# Altium schematic sheet WSN_project_Gateway.SchDoc
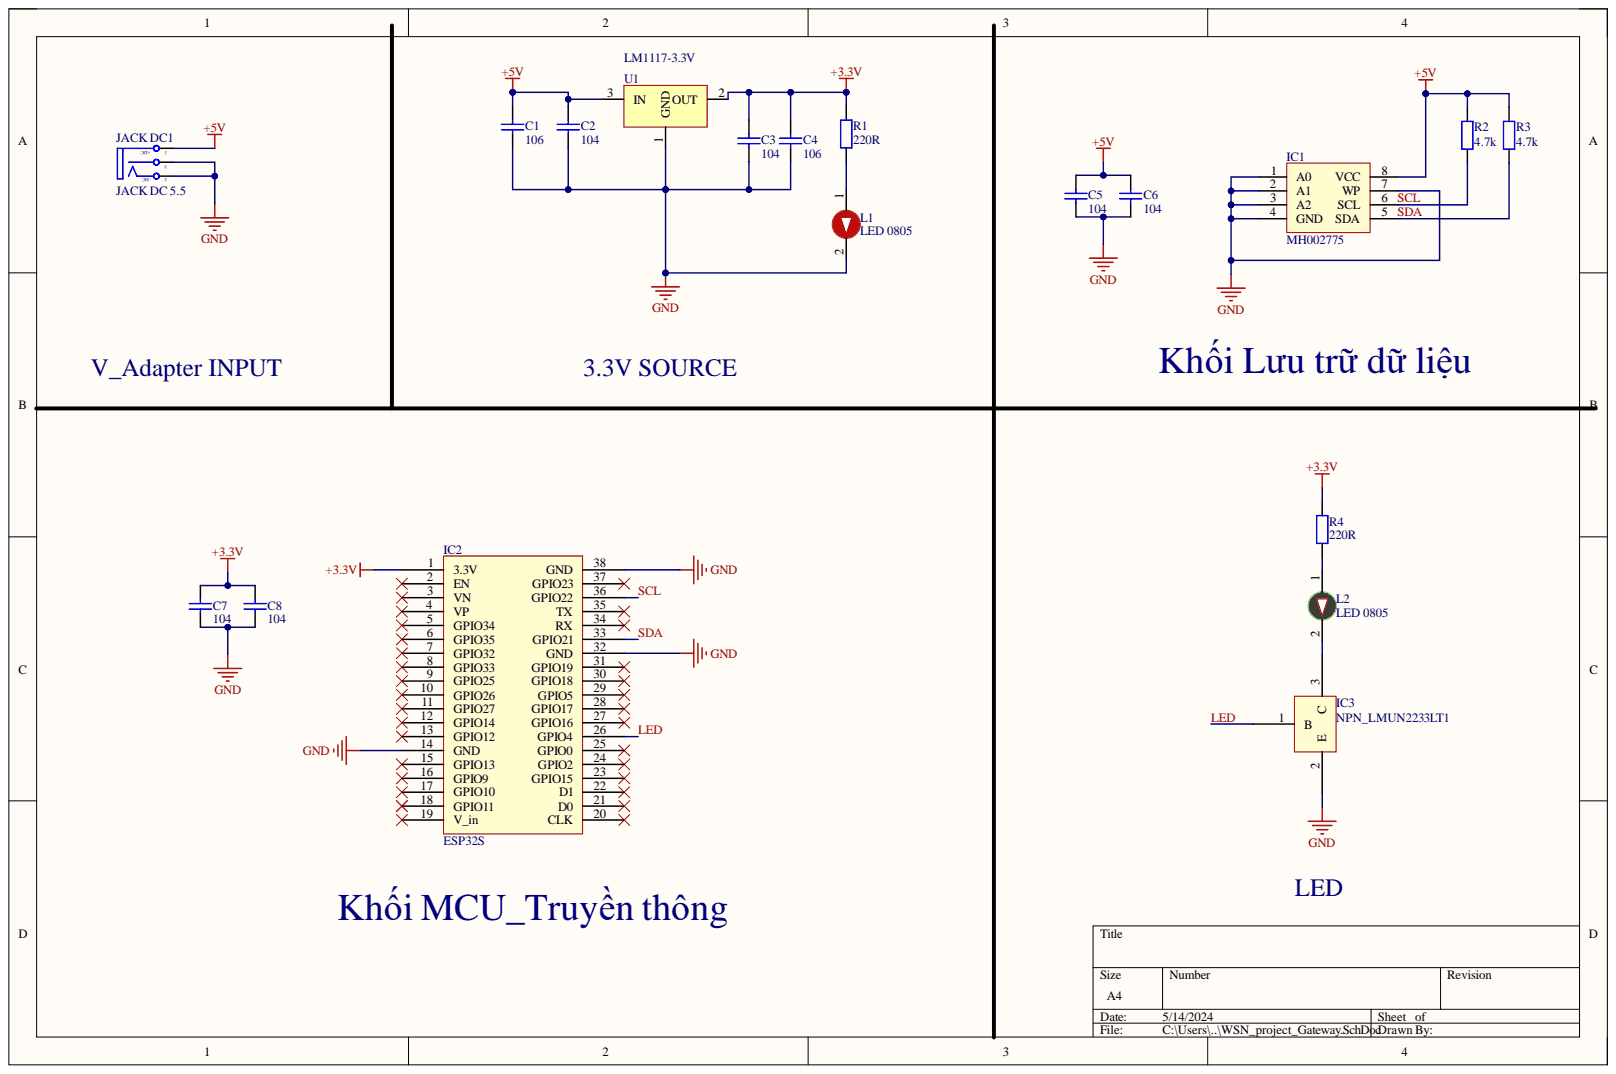
[diagram p0: WSN_project_Gateway.SchDoc]

## Parts
C1  106  [tu 1206]
C2  104  [tu 1206]
C3  104  [tu 1206]
C4  106  [tu 1206]
C5  104  [tu 1206]
C6  104  [tu 1206]
C7  104  [tu 1206]
C8  104  [tu 1206]
IC1  MH002775  [DIP8]
IC2  ESP32S  [ESP32 DEVKIT]
IC3  NPN_LMUN2233LT1  [SOT23_LMUN2233_trans]
JACK_DC1  JACK DC 5.5  [JACK DC 5.5]
L1  LED 0805  [LED-0805-Red]
L2  LED 0805  [LED-1206-Green]
R1  220R  [tro smd 0805]
R2  4.7k  [tro smd 0805]
R3  4.7k  [tro smd 0805]
R4  220R  [tro smd 0805]
U1  LM1117-3.3V  [LM1117-SOP]

## Nets
+3.3V: C3.1 C4.2 C7.1 C8.1 IC2.1(3.3V) R1.1 R4.2 U1.2
+5V: C1.2 C2.1 C5.1 C6.1 IC1.8(VCC) JACK_DC1.1 R2.2 R3.2 U1.3
GND: C1.1 C2.2 C3.2 C4.1 C5.2 C6.2 C7.2 C8.2 IC1.1(A0) IC1.2(A1) IC1.3(A2) IC1.4(GND) IC1.7(WP) IC2.14(GND) IC2.32(GND) IC2.38(GND) IC3.2 JACK_DC1.2 JACK_DC1.3 L1.2 U1.1
LED: IC2.26(GPIO4) IC3.1
SCL: IC1.6(SCL) IC2.36(GPIO22) R2.1
SDA: IC1.5(SDA) IC2.33(GPIO21) R3.1
NetIC3_3: IC3.3 L2.2
NetL1_1: L1.1 R1.2
NetL2_1: L2.1 R4.1

Not connected: IC2 2(EN) 3(VN) 4(VP) 5(GPIO34) 6(GPIO35) 7(GPIO32) 8(GPIO33) 9(GPIO25) 10(GPIO26) 11(GPIO27) 12(GPIO14) 13(GPIO12) 15(GPIO13) 16(GPIO9) 17(GPIO10) 18(GPIO11) 19(V_in) 20(CLK) 21(D0) 22(D1) 23(GPIO15) 24(GPIO2) 25(GPIO0) 27(GPIO16) 28(GPIO17) 29(GPIO5) 30(GPIO18) 31(GPIO19) 34(RX) 35(TX) 37(GPIO23)

## Library data of the parts
C1 C4 = Tu 1206 106 16V 20%: Dán; Don_Vi: Con; Ma_Hang: MH004541; So_Chan: 2; Ten_Linh_Kien: Tụ 1206 106-10uF 20% 16V; Chung_Loai: Dán; Component Kind: Standard; Library Name: Altium_Lib_by_KhueNguyenCreator.IntLib
C2 C3 C5-C8 = Tu 1206 104 50V 20%: Dán; Don_Vi: Con; Ma_Hang: MH004544; So_Chan: 2; Ten_Linh_Kien: Tụ 1206 104-0.1uF 20% 50V; Chung_Loai: Dán; Component Kind: Standard; Library Name: Altium_Lib_by_KhueNguyenCreator.IntLib
IC1 = AT24C01 DIP8 EEPROM: Cắm; Don_Vi: Con; Ten_Linh_Kien: AT24C01 DIP8 EEPROM 1KBIT; Chung_Loai: Cắm; Component Kind: Standard; Ma_Hang: MH002775; Library Name: Altium_Lib_by_KhueNguyenCreator.IntLib
JACK_DC1 = JACK DC 5.5: Value: Jac DC; Component Kind: Standard; Description: JACK DC 5.5; Library Name: Altium_Lib_by_KhueNguyenCreator.IntLib
L1 = LED 0805 Do: Dán; Don_Vi: Con; Ma_Hang: MH000897; Ten_Linh_Kien: LED 0805 Màu Đỏ (Red); Chung_Loai: Dán; Component Kind: Standard; Library Name: Altium_Lib_by_KhueNguyenCreator.IntLib
L2 = LED 1206 Xanh La: Dán; Don_Vi: Con; Ma_Hang: MH002947; Ten_Linh_Kien: LED1206 Màu Xanh Lá (Green); Chung_Loai: Dán; Component Kind: Standard; Library Name: Altium_Lib_by_KhueNguyenCreator.IntLib
R1 = Tro 0805 1% 0.5R: Dán; Don_Vi: Con; Ma_Hang: MH005465; So_Chan: 2; Ten_Linh_Kien: Trở 0805 1% 0.5R; Chung_Loai: Dán; Component Kind: Standard; Library Name: Altium_Lib_by_KhueNguyenCreator.IntLib
R2 R3 = Tro 0805 5% 4.7k: Dán; Don_Vi: Con; Ma_Hang: MH005307; So_Chan: 2; Ten_Linh_Kien: Trở 0805 5% 4.7k; Chung_Loai: Dán; Component Kind: Standard; Library Name: Altium_Lib_by_KhueNguyenCreator.IntLib
R4 = Tro 0805 5% 220R: Dán; Don_Vi: Con; Ma_Hang: MH005259; So_Chan: 2; Ten_Linh_Kien: Trở 0805 5% 220R; Chung_Loai: Dán; Component Kind: Standard; Library Name: Altium_Lib_by_KhueNguyenCreator.IntLib
U1 = LM1117: 800mA Low-Dropout Linear Regulator; Component Kind: Standard; Library Name: My library altium.IntLib; Website: http://group3i.net; Creator: Nguyen Ba Bien
IC2 = ESP32S: Component Kind: Standard; Library Name: Schlib3.SchLib
IC3 = NPN_LMUN2233LT1: Component Kind: Standard; Library Name: Schlib4.SchLib
